# Altium schematic sheet [02] - Microcontroller.SchDoc("[02] - Microcontroller")
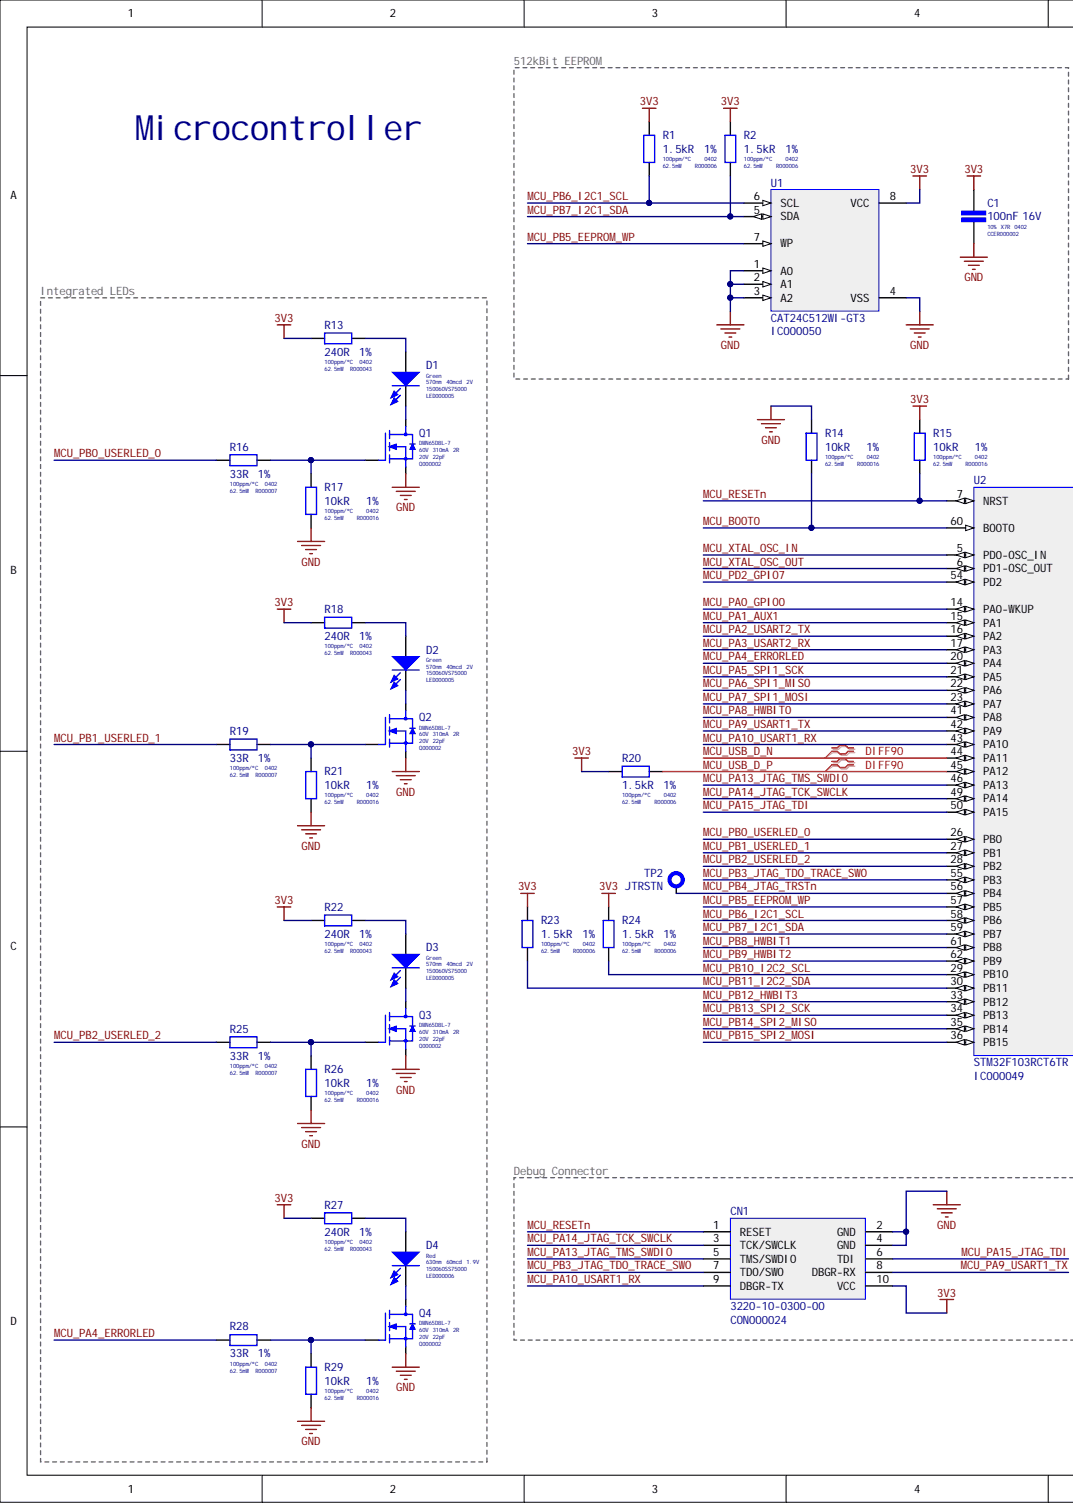
[diagram p0: [02] - Microcontroller.SchDoc, left]
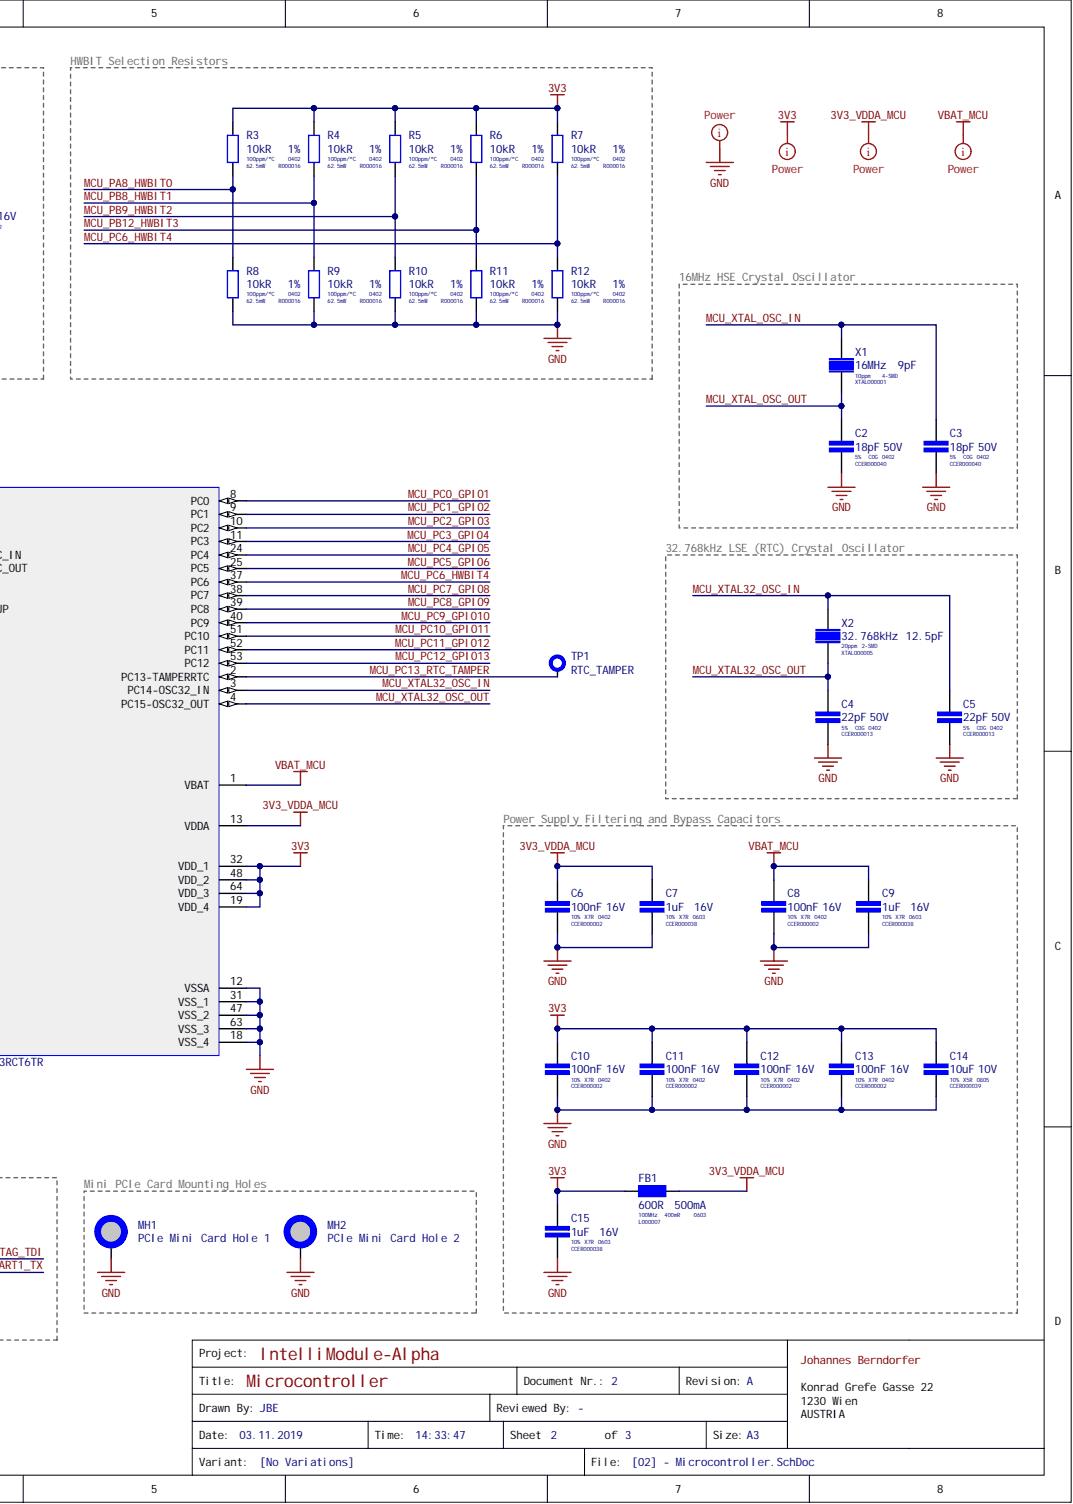
[diagram p1: [02] - Microcontroller.SchDoc, right]

## Parts
C1  100nF 16V  Tolerance: 10%  Internal Part Number: CCER000002  Dielectric: X7R  Case Code (Imperial): 0402  Capacitance: 100nF  [CAP-SMD-0402-0.55MM-IPC-MED]
C2  18pF 50V  Voltage Rating (DC): 50V  Tolerance: 5%  Internal Part Number: CCER000040  Dielectric: C0G  Case Code (Imperial): 0402  Capacitance: 18pF  [CAP-SMD-0402-0.55MM-IPC-MED]
C3  18pF 50V  Voltage Rating (DC): 50V  Tolerance: 5%  Internal Part Number: CCER000040  Dielectric: C0G  Case Code (Imperial): 0402  Capacitance: 18pF  [CAP-SMD-0402-0.55MM-IPC-MED]
C4  22pF 50V  Voltage Rating: 50V  Tolerance: 5%  Internal Part Number: CCER000013  Dielectric: C0G  Case Code (Imperial): 0402  Capacitance: 22pF  [CAP-SMD-0402-0.55MM-IPC-MED]
C5  22pF 50V  Voltage Rating: 50V  Tolerance: 5%  Internal Part Number: CCER000013  Dielectric: C0G  Case Code (Imperial): 0402  Capacitance: 22pF  [CAP-SMD-0402-0.55MM-IPC-MED]
C6  100nF 16V  Tolerance: 10%  Internal Part Number: CCER000002  Dielectric: X7R  Case Code (Imperial): 0402  Capacitance: 100nF  [CAP-SMD-0402-0.55MM-IPC-MED]
C7  1uF 16V  Voltage Rating (DC): 16V  Tolerance: 10%  Internal Part Number: CCER000038  Dielectric: X7R  Case Code (Imperial): 0603  Capacitance: 1uF  [CAP-SMD-0603-0.9MM-IPC-MED]
C8  100nF 16V  Tolerance: 10%  Internal Part Number: CCER000002  Dielectric: X7R  Case Code (Imperial): 0402  Capacitance: 100nF  [CAP-SMD-0402-0.55MM-IPC-MED]
C9  1uF 16V  Voltage Rating (DC): 16V  Tolerance: 10%  Internal Part Number: CCER000038  Dielectric: X7R  Case Code (Imperial): 0603  Capacitance: 1uF  [CAP-SMD-0603-0.9MM-IPC-MED]
C10  100nF 16V  Tolerance: 10%  Internal Part Number: CCER000002  Dielectric: X7R  Case Code (Imperial): 0402  Capacitance: 100nF  [CAP-SMD-0402-0.55MM-IPC-MED]
C11  100nF 16V  Voltage Rating (DC): 16V  Tolerance: 10%  Internal Part Number: CCER000002  Dielectric: X7R  Case Code (Imperial): 0402  Capacitance: 100nF  [CAP-SMD-0402-0.55MM-IPC-MED]
C12  100nF 16V  Voltage Rating (DC): 16V  Tolerance: 10%  Internal Part Number: CCER000002  Dielectric: X7R  Case Code (Imperial): 0402  Capacitance: 100nF  [CAP-SMD-0402-0.55MM-IPC-MED]
C13  100nF 16V  Voltage Rating (DC): 16V  Tolerance: 10%  Internal Part Number: CCER000002  Dielectric: X7R  Case Code (Imperial): 0402  Capacitance: 100nF  [CAP-SMD-0402-0.55MM-IPC-MED]
C14  10uF 10V  Voltage Rating: 10V  Tolerance: 10%  Internal Part Number: CCER000039  Dielectric: X5R  Case Code (Imperial): 0805  Capacitance: 10uF  [CAP-SMD-0805-1.35MM-IPC-MED]
C15  1uF 16V  Voltage Rating (DC): 16V  Tolerance: 10%  Internal Part Number: CCER000038  Dielectric: X7R  Case Code (Imperial): 0603  Capacitance: 1uF  [CAP-SMD-0603-0.9MM-IPC-MED]
CN1  3220-10-0300-00  [CON-SMD-PINHEADER-SHIELDED-1.27MM-10POS-2X5-3220-10-0300-00-CNC-TECH]
D1  150060VS75000  Wavelength: 570nm  Luminous Intensity: 40mcd  Internal Part Number: LED000005  Color: Green  [LED-SMD-0603]
D2  150060VS75000  Wavelength: 570nm  Luminous Intensity: 40mcd  Internal Part Number: LED000005  Color: Green  [LED-SMD-0603]
D3  150060VS75000  Wavelength: 570nm  Luminous Intensity: 40mcd  Internal Part Number: LED000005  Color: Green  [LED-SMD-0603]
D4  150060SS75000  Wavelength: 630nm  Luminous Intensity: 60mcd  Internal Part Number: LED000006  Color: Red  [LED-SMD-0603]
FB1  Max DC Current: 500mA  Internal Part Number: L000007  Impedance: 600R  DC Resistance (DCR): 400mR  Case Code (Imperial): 0603  [IND-SMD-0603-0.75MM-IPC-MED]  (hidden comment: 600R-100MHz 500MA)
MH1  PCIe Mini Card Hole 1  [MECH-HOLE-PTH-2.6MM-5.8MMSQ-PCIEMINI]
MH2  PCIe Mini Card Hole 2  [MECH-HOLE-PTH-2.6MM-5.8MMSQ-PCIEMINI]
Q1  DMN65D8L-7  Internal Part Number: Q000002  Input Capacitance: 22pF  Gate to Source Voltage (Vgs): 20V  Drain to Source Voltage (Vdss): 60V  Continuous Drain Current (ID): 310mA  [SOT-23-3-H1.1MM-DIODES-INC]
Q2  DMN65D8L-7  Internal Part Number: Q000002  Input Capacitance: 22pF  Gate to Source Voltage (Vgs): 20V  Drain to Source Voltage (Vdss): 60V  Continuous Drain Current (ID): 310mA  [SOT-23-3-H1.1MM-DIODES-INC]
Q3  DMN65D8L-7  Internal Part Number: Q000002  Input Capacitance: 22pF  Gate to Source Voltage (Vgs): 20V  Drain to Source Voltage (Vdss): 60V  Continuous Drain Current (ID): 310mA  [SOT-23-3-H1.1MM-DIODES-INC]
Q4  DMN65D8L-7  Internal Part Number: Q000002  Input Capacitance: 22pF  Gate to Source Voltage (Vgs): 20V  Drain to Source Voltage (Vdss): 60V  Continuous Drain Current (ID): 310mA  [SOT-23-3-H1.1MM-DIODES-INC]
R1  1.5kR 1%  Temperature Coefficient: 100ppm/°C  Resistance: 1.5kR  Power Rating: 62.5mW  [RES-SMD-0402-0.4MM-IPC-MED]
R2  1.5kR 1%  Tolerance: 1%  Temperature Coefficient: 100ppm/°C  Resistance: 1.5kR  Power Rating: 62.5mW  Internal Part Number: R000006  Case Code (Imperial): 0402  [RES-SMD-0402-0.4MM-IPC-MED]
R3  Temperature Coefficient: 100ppm/°C  Resistance: 10kR  Power Rating: 62.5mW  [RES-SMD-0402-0.4MM-IPC-MED]  (hidden comment: 10kR 1%)
R4  10kR 1%  Tolerance: 1%  Temperature Coefficient: 100ppm/°C  Resistance: 10kR  Power Rating: 62.5mW  Internal Part Number: R000016  Case Code (Imperial): 0402  [RES-SMD-0402-0.4MM-IPC-MED]
R5  10kR 1%  Tolerance: 1%  Temperature Coefficient: 100ppm/°C  Resistance: 10kR  Power Rating: 62.5mW  Internal Part Number: R000016  Case Code (Imperial): 0402  [RES-SMD-0402-0.4MM-IPC-MED]
R6  10kR 1%  Tolerance: 1%  Temperature Coefficient: 100ppm/°C  Resistance: 10kR  Power Rating: 62.5mW  Internal Part Number: R000016  Case Code (Imperial): 0402  [RES-SMD-0402-0.4MM-IPC-MED]
R7  10kR 1%  Tolerance: 1%  Temperature Coefficient: 100ppm/°C  Resistance: 10kR  Power Rating: 62.5mW  Internal Part Number: R000016  Case Code (Imperial): 0402  [RES-SMD-0402-0.4MM-IPC-MED]
R8  Temperature Coefficient: 100ppm/°C  Resistance: 10kR  Power Rating: 62.5mW  [RES-SMD-0402-0.4MM-IPC-MED]  (hidden comment: 10kR 1%)
R9  10kR 1%  Tolerance: 1%  Temperature Coefficient: 100ppm/°C  Resistance: 10kR  Power Rating: 62.5mW  Internal Part Number: R000016  Case Code (Imperial): 0402  [RES-SMD-0402-0.4MM-IPC-MED]
R10  10kR 1%  Tolerance: 1%  Temperature Coefficient: 100ppm/°C  Resistance: 10kR  Power Rating: 62.5mW  Internal Part Number: R000016  Case Code (Imperial): 0402  [RES-SMD-0402-0.4MM-IPC-MED]
R11  10kR 1%  Tolerance: 1%  Temperature Coefficient: 100ppm/°C  Resistance: 10kR  Power Rating: 62.5mW  Internal Part Number: R000016  Case Code (Imperial): 0402  [RES-SMD-0402-0.4MM-IPC-MED]
R12  10kR 1%  Tolerance: 1%  Temperature Coefficient: 100ppm/°C  Resistance: 10kR  Power Rating: 62.5mW  Internal Part Number: R000016  Case Code (Imperial): 0402  [RES-SMD-0402-0.4MM-IPC-MED]
R13  240R 1%  Tolerance: 1%  Temperature Coefficient: 100ppm/°C  Resistance: 240R  Power Rating: 62.5mW  Case/Package: 0402  [RES-SMD-0402-0.4MM-IPC-MED]
R14  Temperature Coefficient: 100ppm/°C  Resistance: 10kR  Power Rating: 62.5mW  [RES-SMD-0402-0.4MM-IPC-MED]  (hidden comment: 10kR 1%)
R15  Temperature Coefficient: 100ppm/°C  Resistance: 10kR  Power Rating: 62.5mW  [RES-SMD-0402-0.4MM-IPC-MED]  (hidden comment: 10kR 1%)
R16  33R 1%  Tolerance: 1%  Temperature Coefficient: 100ppm/°C  Resistance: 33R  Power Rating: 62.5mW  Internal Part Number: R000007  Case Code (Imperial): 0402  [RES-SMD-0402-0.4MM-IPC-MED]
R17  Temperature Coefficient: 100ppm/°C  Resistance: 10kR  Power Rating: 62.5mW  [RES-SMD-0402-0.4MM-IPC-MED]  (hidden comment: 10kR 1%)
R18  240R 1%  Tolerance: 1%  Temperature Coefficient: 100ppm/°C  Resistance: 240R  Power Rating: 62.5mW  Case/Package: 0402  [RES-SMD-0402-0.4MM-IPC-MED]
R19  33R 1%  Tolerance: 1%  Temperature Coefficient: 100ppm/°C  Resistance: 33R  Power Rating: 62.5mW  Internal Part Number: R000007  Case Code (Imperial): 0402  [RES-SMD-0402-0.4MM-IPC-MED]
R20  1.5kR 1%  Tolerance: 1%  Temperature Coefficient: 100ppm/°C  Resistance: 1.5kR  Power Rating: 62.5mW  Internal Part Number: R000006  Case Code (Imperial): 0402  [RES-SMD-0402-0.4MM-IPC-MED]
R21  Temperature Coefficient: 100ppm/°C  Resistance: 10kR  Power Rating: 62.5mW  [RES-SMD-0402-0.4MM-IPC-MED]  (hidden comment: 10kR 1%)
R22  240R 1%  Tolerance: 1%  Temperature Coefficient: 100ppm/°C  Resistance: 240R  Power Rating: 62.5mW  Case/Package: 0402  [RES-SMD-0402-0.4MM-IPC-MED]
R23  1.5kR 1%  Temperature Coefficient: 100ppm/°C  Resistance: 1.5kR  Power Rating: 62.5mW  [RES-SMD-0402-0.4MM-IPC-MED]
R24  1.5kR 1%  Tolerance: 1%  Temperature Coefficient: 100ppm/°C  Resistance: 1.5kR  Power Rating: 62.5mW  Internal Part Number: R000006  Case Code (Imperial): 0402  [RES-SMD-0402-0.4MM-IPC-MED]
R25  33R 1%  Tolerance: 1%  Temperature Coefficient: 100ppm/°C  Resistance: 33R  Power Rating: 62.5mW  Internal Part Number: R000007  Case Code (Imperial): 0402  [RES-SMD-0402-0.4MM-IPC-MED]
R26  Temperature Coefficient: 100ppm/°C  Resistance: 10kR  Power Rating: 62.5mW  [RES-SMD-0402-0.4MM-IPC-MED]  (hidden comment: 10kR 1%)
R27  240R 1%  Tolerance: 1%  Temperature Coefficient: 100ppm/°C  Resistance: 240R  Power Rating: 62.5mW  Internal Part Number: R000043  Case/Package: 0402  [RES-SMD-0402-0.4MM-IPC-MED]
R28  33R 1%  Tolerance: 1%  Temperature Coefficient: 100ppm/°C  Resistance: 33R  Power Rating: 62.5mW  Internal Part Number: R000007  Case Code (Imperial): 0402  [RES-SMD-0402-0.4MM-IPC-MED]
R29  Temperature Coefficient: 100ppm/°C  Resistance: 10kR  Power Rating: 62.5mW  [RES-SMD-0402-0.4MM-IPC-MED]  (hidden comment: 10kR 1%)
TP1  RTC_TAMPER  [TP-SMD-CIRCULAR-0.5MM]
TP2  JTRSTN  [TP-SMD-CIRCULAR-0.5MM]
U1  CAT24C512WI-GT3  Internal Part Number: IC000050  [SOIC-8-H1.75MM-P1.27MM-ONSEMI]
U2  STM32F103RCT6TR  Internal Part Number: IC000049  [LQFP-64-10X10MM-H1.6MM-P0.5MM-ST]
X1  16MHz 9pF  Internal Part Number: XTAL000001  Frequency Tolerance: 10ppm  Frequency: 16MHz  Case/Package: 4-SMD  [XTAL-SMD-4-SMD-3.2X2.5MM]
X2  32.768kHz 12.5pF  Internal Part Number: XTAL000005  Frequency Tolerance: 20ppm  Frequency: 32.768kHz  Case/Package: 2-SMD  [XTAL-SMD-2-SMD-3.2X1.5MM]

## Nets
3V3: C1.1 C10.1 C11.1 C12.1 C13.1 C14.1 C15.1 CN1.10(VCC) FB1.1 R1.1 R2.1 R3.1 R4.1 R5.1 R6.1 R7.1 R13.1 R15.1 R18.1 R20.1 R22.1 R23.1 R24.1 R27.1 U1.8(VCC) U2.19(VDD_4) U2.32(VDD_1) U2.48(VDD_2) U2.64(VDD_3)
3V3_VDDA_MCU: C6.1 C7.1 FB1.2 U2.13(VDDA)
GND: C1.2 C2.2 C3.2 C4.2 C5.2 C6.2 C7.2 C8.2 C9.2 C10.2 C11.2 C12.2 C13.2 C14.2 C15.2 CN1.2(GND) CN1.4(GND) MH1.1 MH2.1 Q1.2 Q2.2 Q3.2 Q4.2 R8.2 R9.2 R10.2 R11.2 R12.2 R14.1 R17.2 R21.2 R26.2 R29.2 U1.1(A0) U1.2(A1) U1.3(A2) U1.4(VSS) U2.12(VSSA) U2.18(VSS_4) U2.31(VSS_1) U2.47(VSS_2) U2.63(VSS_3)
VBAT_MCU: C8.1 C9.1 U2.1(VBAT)
MCU_BOOT0: R14.2 U2.60(BOOT0)
MCU_PA0_GPIO0: U2.14(PA0-WKUP)
MCU_PA1_AUX1: U2.15(PA1)
MCU_PA2_USART2_TX: U2.16(PA2)
MCU_PA3_USART2_RX: U2.17(PA3)
MCU_PA4_ERRORLED: R28.1 U2.20(PA4)
MCU_PA5_SPI1_SCK: U2.21(PA5)
MCU_PA6_SPI1_MISO: U2.22(PA6)
MCU_PA7_SPI1_MOSI: U2.23(PA7)
MCU_PA8_HWBIT0: R3.2 R8.1 U2.41(PA8)
MCU_PA9_USART1_TX: CN1.8(DBGR-RX) U2.42(PA9)
MCU_PA10_USART1_RX: CN1.9(DBGR-TX) U2.43(PA10)
MCU_PA13_JTAG_TMS_SWDIO: CN1.5(TMS/SWDIO) U2.46(PA13)
MCU_PA14_JTAG_TCK_SWCLK: CN1.3(TCK/SWCLK) U2.49(PA14)
MCU_PA15_JTAG_TDI: CN1.6(TDI) U2.50(PA15)
MCU_PB0_USERLED_0: R16.1 U2.26(PB0)
MCU_PB1_USERLED_1: R19.1 U2.27(PB1)
MCU_PB2_USERLED_2: R25.1 U2.28(PB2)
MCU_PB3_JTAG_TDO_TRACE_SWO: CN1.7(TDO/SWO) U2.55(PB3)
MCU_PB4_JTAG_TRSTn: TP2.1 U2.56(PB4)
MCU_PB5_EEPROM_WP: U1.7(WP) U2.57(PB5)
MCU_PB6_I2C1_SCL: R1.2 U1.6(SCL) U2.58(PB6)
MCU_PB7_I2C1_SDA: R2.2 U1.5(SDA) U2.59(PB7)
MCU_PB8_HWBIT1: R4.2 R9.1 U2.61(PB8)
MCU_PB9_HWBIT2: R5.2 R10.1 U2.62(PB9)
MCU_PB10_I2C2_SCL: R24.2 U2.29(PB10)
MCU_PB11_I2C2_SDA: R23.2 U2.30(PB11)
MCU_PB12_HWBIT3: R6.2 R11.1 U2.33(PB12)
MCU_PB13_SPI2_SCK: U2.34(PB13)
MCU_PB14_SPI2_MISO: U2.35(PB14)
MCU_PB15_SPI2_MOSI: U2.36(PB15)
MCU_PC0_GPIO1: U2.8(PC0)
MCU_PC1_GPIO2: U2.9(PC1)
MCU_PC2_GPIO3: U2.10(PC2)
MCU_PC3_GPIO4: U2.11(PC3)
MCU_PC4_GPIO5: U2.24(PC4)
MCU_PC5_GPIO6: U2.25(PC5)
MCU_PC6_HWBIT4: R7.2 R12.1 U2.37(PC6)
MCU_PC7_GPIO8: U2.38(PC7)
MCU_PC8_GPIO9: U2.39(PC8)
MCU_PC9_GPIO10: U2.40(PC9)
MCU_PC10_GPIO11: U2.51(PC10)
MCU_PC11_GPIO12: U2.52(PC11)
MCU_PC12_GPIO13: U2.53(PC12)
MCU_PC13_RTC_TAMPER: TP1.1 U2.2(PC13-TAMPERRTC)
MCU_PD2_GPIO7: U2.54(PD2)
MCU_RESETn: CN1.1(RESET) R15.2 U2.7(NRST)
MCU_USB_D_N: U2.44(PA11)
MCU_USB_D_P: R20.2 U2.45(PA12)
MCU_XTAL32_OSC_IN: C5.1 U2.3(PC14-OSC32_IN) X2.1
MCU_XTAL32_OSC_OUT: C4.1 U2.4(PC15-OSC32_OUT) X2.2
MCU_XTAL_OSC_IN: C3.1 U2.5(PD0-OSC_IN) X1.1
MCU_XTAL_OSC_OUT: C2.1 U2.6(PD1-OSC_OUT) X1.3
NetD1_1: D1.1 Q1.3
NetD1_2: D1.2 R13.2
NetD2_1: D2.1 Q2.3
NetD2_2: D2.2 R18.2
NetD3_1: D3.1 Q3.3
NetD3_2: D3.2 R22.2
NetD4_1: D4.1 Q4.3
NetD4_2: D4.2 R27.2
NetQ1_1: Q1.1 R16.2 R17.1
NetQ2_1: Q2.1 R19.2 R21.1
NetQ3_1: Q3.1 R25.2 R26.1
NetQ4_1: Q4.1 R28.2 R29.1
